# Altium schematic sheet Sch_Imp.SchDoc
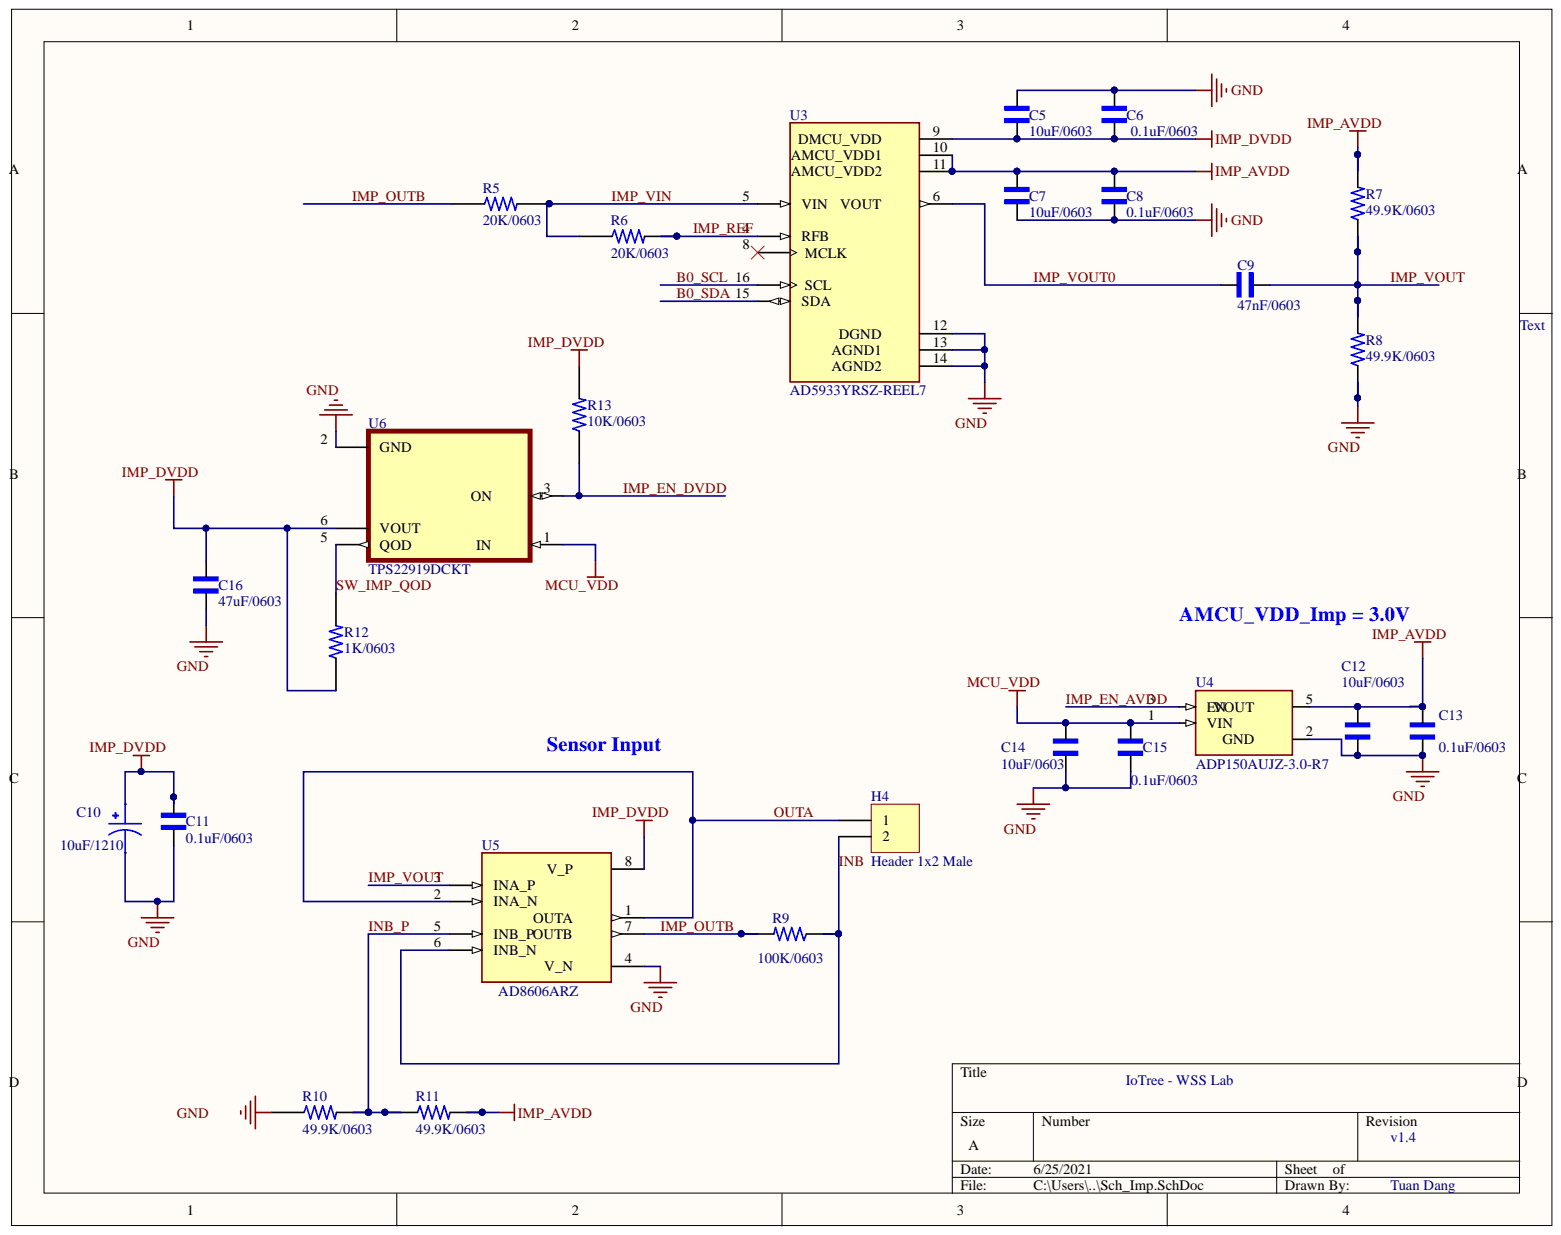
[diagram p0: Sch_Imp.SchDoc]

## Parts
C5  10uF/0603  [CAP-0603]
C6  0.1uF/0603  [CAP-0603]
C7  10uF/0603  [CAP-0603]
C8  0.1uF/0603  [CAP-0603]
C9  47nF/0603  [CAP-0603]
C10  10uF/1210  [POLE CAP 1210]
C11  0.1uF/0603  [CAP-0603]
C12  10uF/0603  [CAP-0603]
C13  0.1uF/0603  [CAP-0603]
C14  10uF/0603  [CAP-0603]
C15  0.1uF/0603  [CAP-0603]
C16  47uF/0603  [CAP-0603]
H4  Header 1x2 Male  [HDR1X2]
R5  20K/0603  [RES-0603]
R6  20K/0603  [RES-0603]
R7  49.9K/0603  [RES-0603]
R8  49.9K/0603  [RES-0603]
R9  100K/0603  [RES-0603]
R10  49.9K/0603  [RES-0603]
R11  49.9K/0603  [RES-0603]
R12  1K/0603  [RES-0603]
R13  10K/0603  [RES-0603]
U3  AD5933YRSZ-REEL7  [SSOP16]
U4  ADP150AUJZ-3.0-R7  [TSOT 5]
U5  AD8606ARZ  [SOIC-8]
U6  TPS22919DCKT  [SOT65]

## Nets
GND: C5.2 C6.2 C7.2 C8.2 C10.2 C11.2 C12.2 C13.2 C14.2 C15.2 C16.2 R8.1 R10.1 U3.12 U3.13 U3.14 U4.2 U5.4 U6.2(GND)
IMP_AVDD: C7.1 C8.1 C12.1 C13.1 R7.2 R11.2 U3.10 U3.11 U4.5
IMP_DVDD: C5.1 C6.1 C10.1 C11.1 C16.1 R12.1 R13.2 U3.9 U5.8 U6.6(VOUT)
MCU_VDD: C14.1 C15.1 U4.1(VIN) U6.1
B0_SCL: U3.16(SCL)
B0_SDA: U3.15(SDA)
IMP_EN_AVDD: U4.3(EN)
IMP_EN_DVDD: R13.1 U6.3
IMP_OUTB: R5.1 R9.2 U5.7
IMP_REF: R6.2 U3.4(RFB)
IMP_VIN: R5.2 R6.1 U3.5(VIN)
IMP_VOUT: C9.2 R7.1 R8.2 U5.3(INA_P)
IMP_VOUT0: C9.1 U3.6
INB: H4.2 R9.1 U5.6(INB_N)
INB_P: R10.2 R11.1 U5.5(INB_P)
OUTA: H4.1 U5.1 U5.2(INA_N)
SW_IMP_QOD: R12.2 U6.5(QOD)

Not connected: U3 8(MCLK)

## Library data of the parts
C5-C9 C11-C16 = Cap 0603: Ceramic Capacitor; MF: AVX; MP: LD031A330JAB2A_0; Availability: Unavailable; Component Kind: Standard; Package: 0603 AVX; Price: None; SnapEDA_Link: https://www.snapeda.com/parts/LD031A330JAB2A%5C500/AVX/view-part/2029942/?ref=snap; Library Name: tuandang.SchLib
C10 = Pole Cap 1210 10uF: Component Kind: Standard; Library Name: tuandang.SchLib
H4 = Header 2: Header, 2-Pin; Component Kind: Standard; LatestRevisionDate: 17-Jul-2002; LatestRevisionNote: Re-released for DXP Platform.; Library Name: Miscellaneous Connectors.IntLib; Signal Integrity: Connector; Publisher: Altium Limited
R5-R13 = Resistor 0603: CRG0603 1% 4K75; MP: 1-1622960-0; Availability: Unavailable; Component Kind: Standard; Package: None; Price: None; Library Name: tuandang.SchLib
U6 = TPS22919DCKT: 5.5-V, 1.5-A, 90-m? load switch with adj. output discharge 6-SC70 -40 to 105; MF: Texas Instruments; Availability: Unavailable; Component Kind: Standard; DigiKey-Purchase-URL: https://snapeda.com/shop?store=DigiKey&id=3662569; Mouser-Purchase-URL: https://snapeda.com/shop?store=Mouser&id=3662569; MP: TPS22919DCKT; Package: SOT-SC70-6 Texas Instruments; Price: None; SnapEDA_Link: https://www.snapeda.com/parts/TPS22919DCKT/Texas%20Instruments/view-part/3662569/?ref=snap; Texas_Instruments-Purchase-URL: https://snapeda.com/shop?store=Texas+Instruments&id=3662569; Library Name: tuandang.SchLib
U3 = AD5933YRSZ-REEL7: Component Kind: Standard; Library Name: tuandang.SchLib
U4 = ADP150AUJZ-3.0-R7: Component Kind: Standard; Library Name: tuandang.SchLib
U5 = AD8606ARZ: Component Kind: Standard; Library Name: tuandang.SchLib
